# Altium schematic sheet ultrasonic_tx.SchDoc
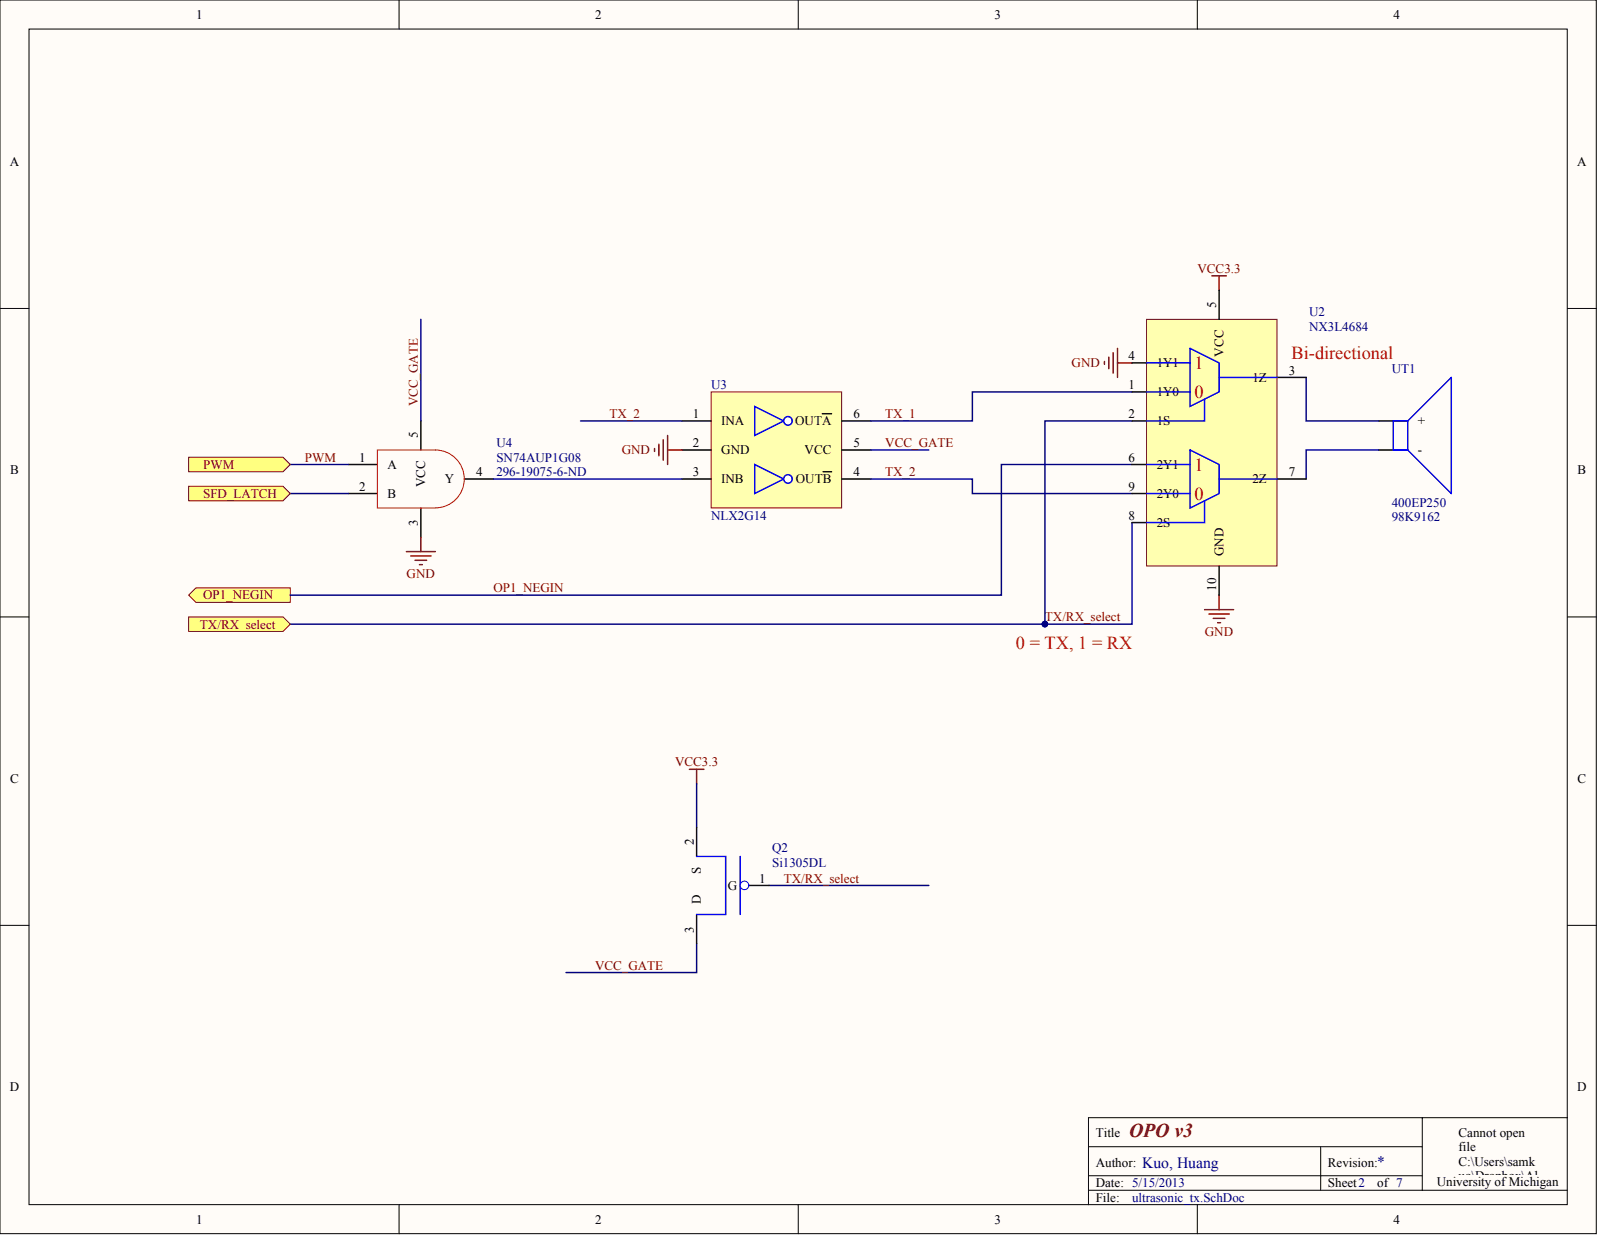
[diagram p0: ultrasonic_tx.SchDoc]

## Parts
Q2  Si1305DL
U2  NX3L4684
U3  NLX2G14
U4  SN74AUP1G08  Supplier Part Number 1: 296-19075-6-ND
UT1  400EP250  Supplier Part Number 1: 98K9162

## Ports
OP1_NEGIN, PWM, SFD_LATCH, TX/RX_SELECT

## Nets
GND: U2.4(1Y1) U2.10(GND) U3.2(GND) U4.3
VCC3.3: Q2.2 U2.5(VCC)
OP1_NEGIN: U2.6(2Y1) ; port OP1_NEGIN
PWM: U4.1(A) ; port PWM
SFD_LATCH: U4.2(B) ; port SFD_LATCH
TX/RX_SELECT: Q2.1 U2.2(1S) U2.8(2S) ; port TX/RX_SELECT
TX_1: U2.1(1Y0) U3.6(OUTA)
TX_2: U2.9(2Y0) U3.1(INA) U3.4(OUTB)
VCC_GATE: Q2.3 U3.5(VCC) U4.5(VCC)
NetU2_3: U2.3(1Z) UT1.2
NetU2_7: U2.7(2Z) UT1.1
NetU3_3: U3.3(INB) U4.4(Y)

## Library data of the parts
Q2 U2-U4 UT1 = (no symbol name)
  Q2: Supplier 1: Digi-Key; Supplier Part Number 1: SI1305DL-T1-GE3DKR-ND
  U2: Supplier 1: Digi-Key; Supplier Part Number 1: 568-5564-1-ND
  U3: Supplier 1: Digi-Key; Supplier Part Number 1: NLX2G14AMX1TCGOSCT-ND
  U4: Supplier 1: Digi-Key
  UT1: Supplier 1: Newark
